# Altium schematic sheet MINIECO-ECO-COMUNICAZIONI.SchDoc(MINIECO-ECO-COMUNICAZIONI)
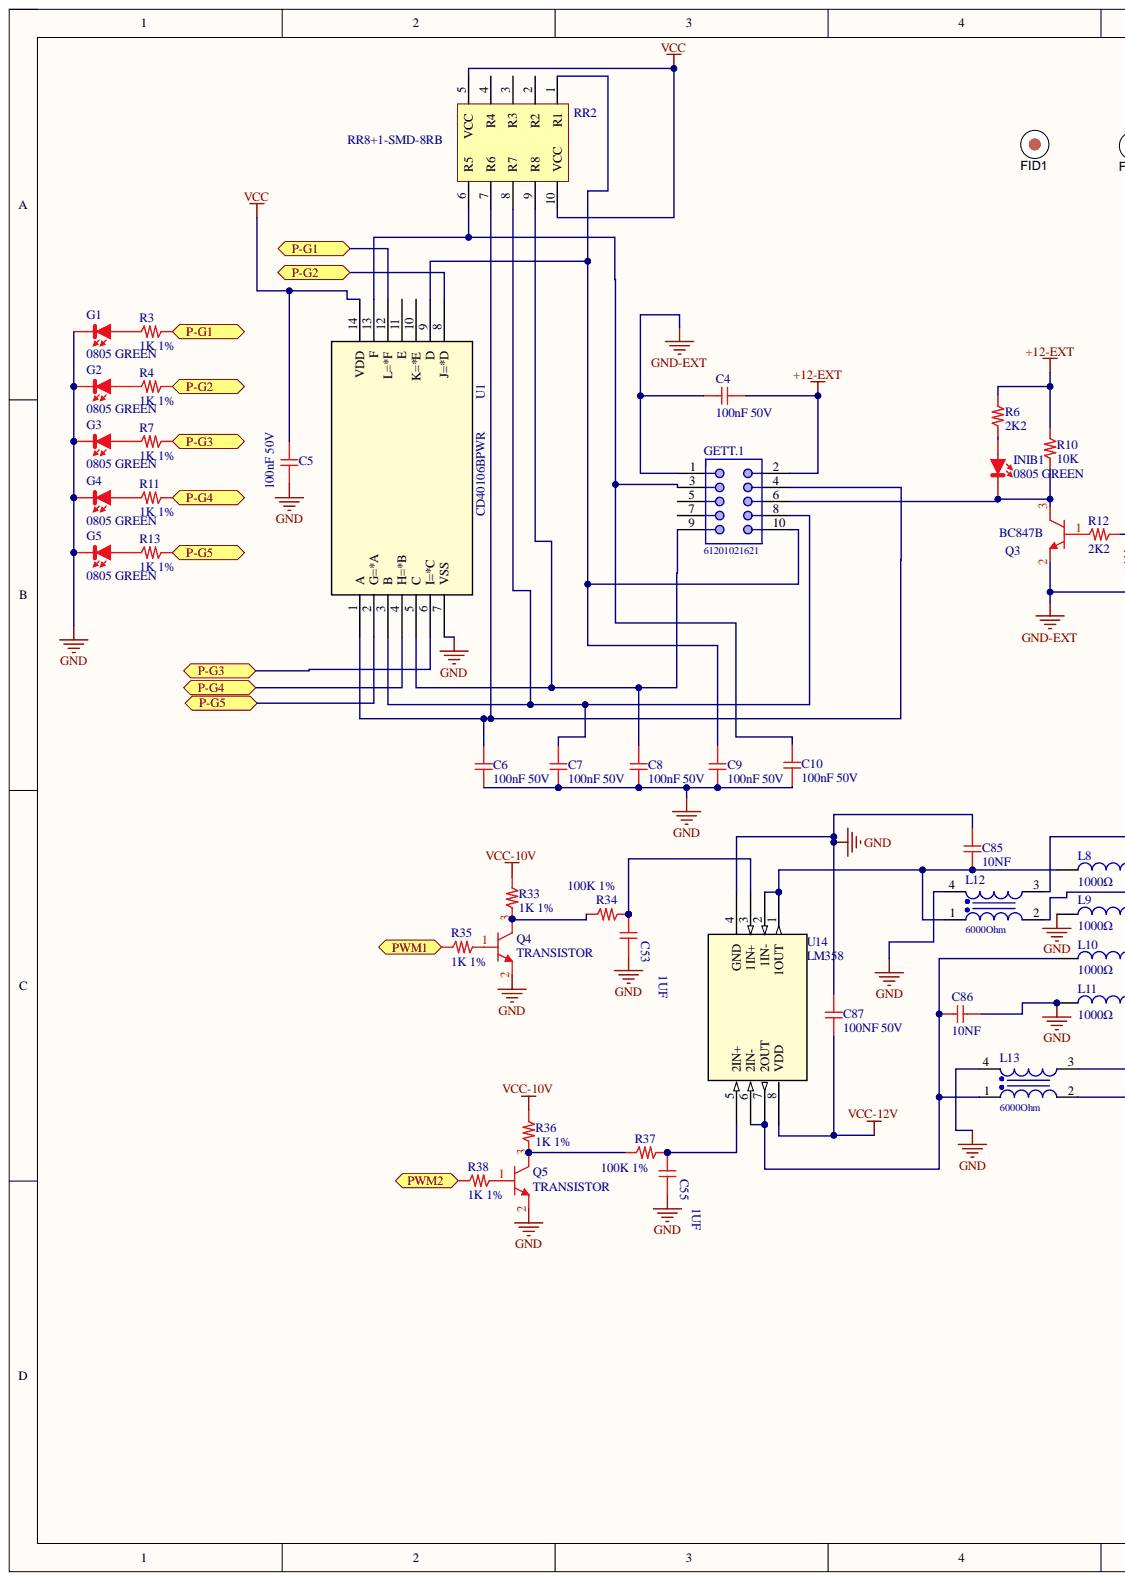
[diagram p0: MINIECO-ECO-COMUNICAZIONI.SchDoc, left]
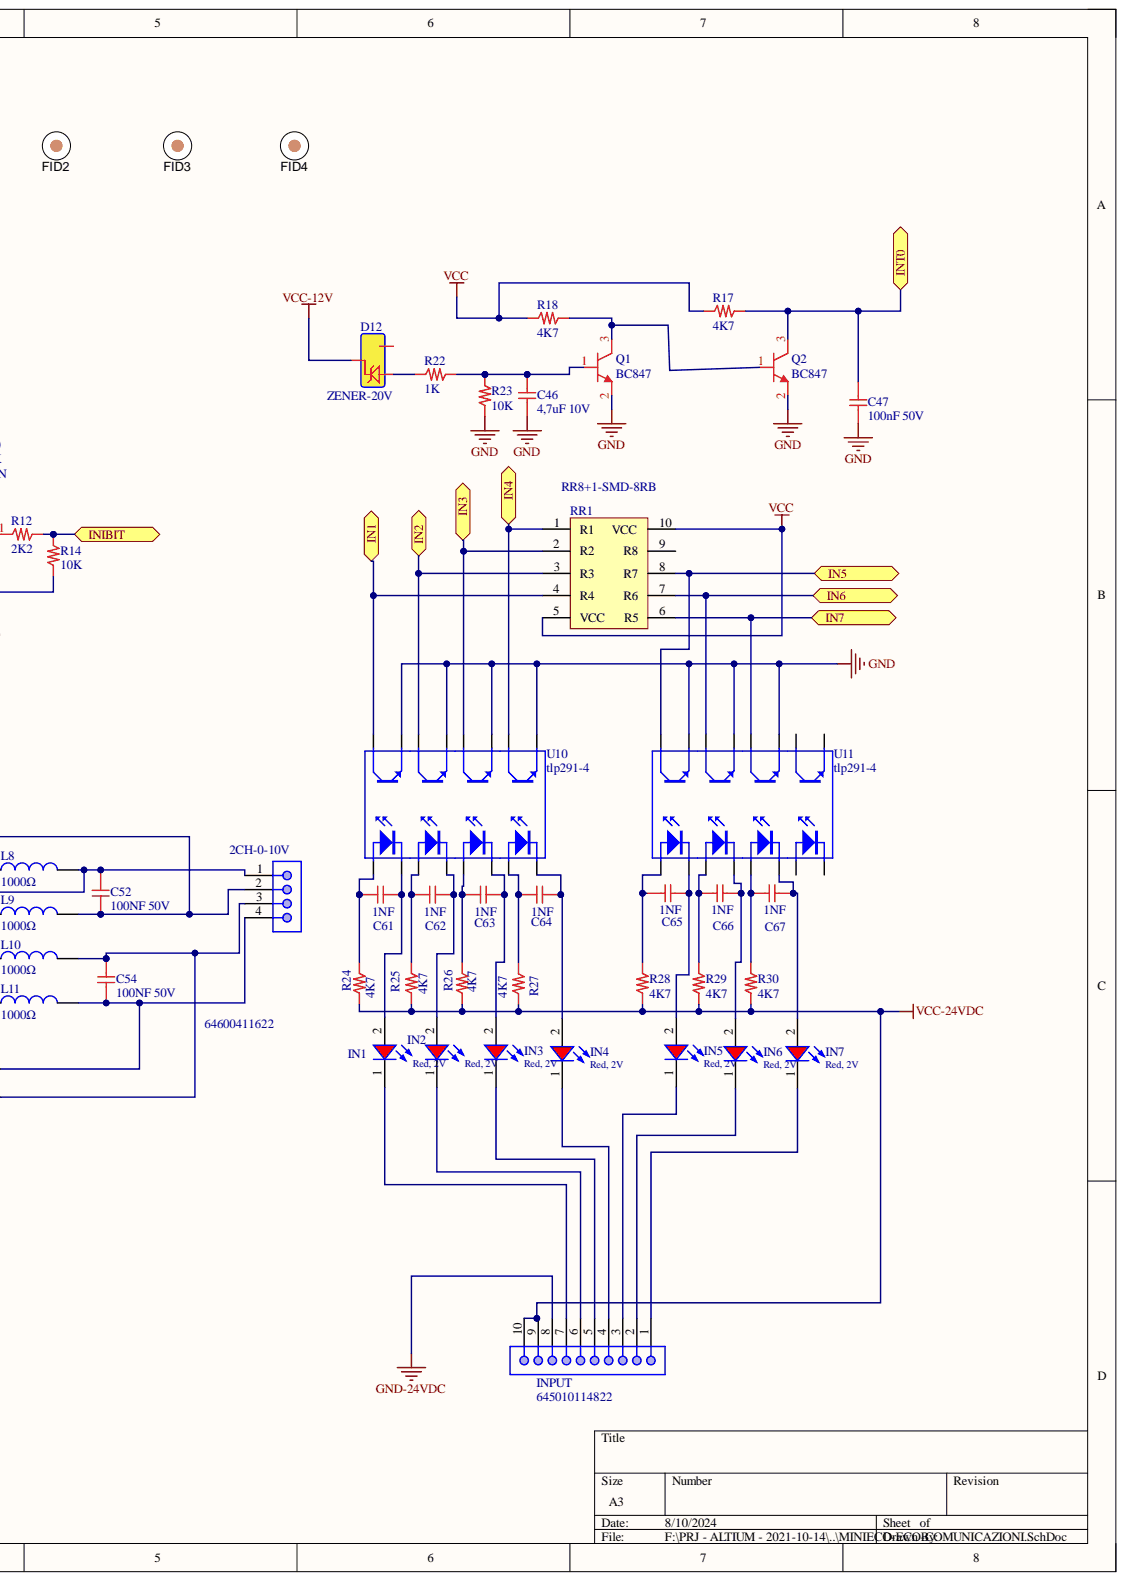
[diagram p1: MINIECO-ECO-COMUNICAZIONI.SchDoc, right]

## Parts
2CH-0-10V  64600411622  [64600411622]
C4  100nF 50V  [0603_c]
C5  100nF 50V  [0603_c]
C6  100nF 50V  [0603_c]
C7  100nF 50V  [0603_c]
C8  100nF 50V  [0603_c]
C9  100nF 50V  [0603_c]
C10  100nF 50V  [0603_c]
C46  4,7uF 10V  [0603_c]
C47  100nF 50V  [0603_c]
C52  100NF 50V  [0603_c]
C53  1UF  [0805_C]
C54  100NF 50V  [0603_c]
C55  1UF  [0805_C]
C61  1NF  [0402_C]
C62  1NF  [0402_C]
C63  1NF  [0402_C]
C64  1NF  [0402_C]
C65  1NF  [0402_C]
C66  1NF  [0402_C]
C67  1NF  [0402_C]
C85  10NF  [0603_c]
C86  10NF  [0603_c]
C87  100NF 50V  [0603_c]
D12  ZENER-20V  [SOT23]
FID1  FIDUCIAL-HSW  [FIDUCIAL HSW]  (value not drawn)
FID2  FIDUCIAL-HSW  [FIDUCIAL HSW]  (value not drawn)
FID3  FIDUCIAL-HSW  [FIDUCIAL HSW]  (value not drawn)
FID4  FIDUCIAL-HSW  [FIDUCIAL HSW]  (value not drawn)
G1  0805 GREEN  [0805_Red]
G2  0805 GREEN  [0805_Red]
G3  0805 GREEN  [0805_Red]
G4  0805 GREEN  [0805_Red]
G5  0805 GREEN  [0805_Red]
GETT.1  61201021621  [61201021621]
IN1  Red, 2V  [WL-SMCW_0603_H=0.25mm]
IN2  Red, 2V  Forward Voltage typ.: 2V  [WL-SMCW_0603_H=0.25mm]
IN3  Red, 2V  Forward Voltage typ.: 2V  [WL-SMCW_0603_H=0.25mm]
IN4  Red, 2V  Forward Voltage typ.: 2V  [WL-SMCW_0603_H=0.25mm]
IN5  Red, 2V  Forward Voltage typ.: 2V  [WL-SMCW_0603_H=0.25mm]
IN6  Red, 2V  Forward Voltage typ.: 2V  [WL-SMCW_0603_H=0.25mm]
IN7  Red, 2V  Forward Voltage typ.: 2V  [WL-SMCW_0603_H=0.25mm]
INIB1  0805 GREEN  [0805_Red]
INPUT  645010114822  [645010114822]
L8  1000Ω  [WE-CBF_0805_Wide Band]
L9  1000Ω  [WE-CBF_0805_Wide Band]
L10  1000Ω  [WE-CBF_0805_Wide Band]
L11  1000Ω  [WE-CBF_0805_Wide Band]
L12  6000Ohm  [WE-CNSW_1206]
L13  6000Ohm  [WE-CNSW_1206]
Q1  BC847  [SOT23]
Q2  BC847  [SOT23]
Q3  BC847B  [SOT23]
Q4  TRANSISTOR  [SOT23]
Q5  TRANSISTOR  [SOT23]
R3  1K 1%  [0603_R]
R4  1K 1%  [0603_R]
R6  2K2  [0603_R]
R7  1K 1%  [0603_R]
R10  10K  [0603_R]
R11  1K 1%  [0603_R]
R12  2K2  [0603_R]
R13  1K 1%  [0603_R]
R14  10K  [0603_R]
R17  4K7  [0603_R]
R18  4K7  [0603_R]
R22  1K  [0603_R]
R23  10K  [0603_R]
R24  4K7  [2512_R]
R25  4K7  [2512_R]
R26  4K7  [2512_R]
R27  4K7  [2512_R]
R28  4K7  [2512_R]
R29  4K7  [2512_R]
R30  4K7  [2512_R]
R33  1K 1%  [0603_R]
R34  100K 1%  [0603_R]
R35  1K 1%  [0603_R]
R36  1K 1%  [0603_R]
R37  100K 1%  [0603_R]
R38  1K 1%  [0603_R]
RR1  RR8+1-SMD-8RB  [RR8+1-SMD]
RR2  RR8+1-SMD-8RB  [RR8+1-SMD]
U1  CD40106BPWR  [PW14-M]
U10  tlp291-4  [SO16_NO]
U11  tlp291-4  [SO16_NO]
U14  LM358  [SO-8]

## Ports
IN1, IN2, IN3, IN4, IN5, IN6, IN7, INIBIT, INT0, P-G1, P-G2, P-G3, P-G4, P-G5, PWM1, PWM2

## Nets
+12-EXT: C4.2 GETT.1.2 R6.1 R10.1
GND: C5.1 C6.1 C7.1 C8.1 C9.1 C10.1 C46.2 C47.2 C53.2 C55.2 C85.1 C86.2 C87.2 G1.K G2.K G3.K G4.K G5.K L9.1 L11.1 L12.4 L13.4 Q1.2 Q2.2 Q4.2 Q5.2 R23.2 U1.7(VSS) U10.9 U10.11 U10.13 U10.15 U11.11 U11.13 U11.15 U14.4(GND)
GND-24VDC: INPUT.8
GND-EXT: C4.1 GETT.1.1 Q3.2 R14.2
VCC: C5.2 R17.2 R18.2 RR1.5(VCC) RR1.10(VCC) RR2.5(VCC) RR2.10(VCC) U1.14(VDD)
VCC-10V: R33.1 R36.1
VCC-12V: C87.1 D12.3 U14.8(VDD)
VCC-24VDC: INPUT.9 INPUT.10 R24.2 R25.2 R26.2 R27.2 R28.2 R29.2 R30.2
IN1: RR1.4(R4) U10.16 ; port IN1
IN2: RR1.3(R3) U10.14 ; port IN2
IN3: RR1.2(R2) U10.12 ; port IN3
IN4: RR1.1(R1) U10.10 ; port IN4
IN5: RR1.8(R7) U11.16 ; port IN5
IN6: RR1.7(R6) U11.14 ; port IN6
IN7: RR1.6(R5) U11.12 ; port IN7
INIBIT: R12.2 R14.1 ; port INIBIT
INT0: C47.1 Q2.3 R17.1 ; port INT0
P-G1: R3.2 U1.12(L=*F) ; port P-G1
P-G2: R4.2 U1.8(J=*D) ; port P-G2
P-G3: R7.2 U1.6(I=*C) ; port P-G3
P-G4: R11.2 U1.4(H=*B) ; port P-G4
P-G5: R13.2 U1.2(G=*A) ; port P-G5
PWM1: R35.1 ; port PWM1
PWM2: R38.1 ; port PWM2
Net2CH-0-10V_1: 2CH-0-10V.1 C52.1 L8.2 L12.2
Net2CH-0-10V_2: 2CH-0-10V.2 C52.2 L9.2 L12.3
Net2CH-0-10V_3: 2CH-0-10V.3 C54.1 L10.2 L13.2
Net2CH-0-10V_4: 2CH-0-10V.4 C54.2 L11.2 L13.3
NetC6_2: C6.2 GETT.1.4 RR2.7(R6) U1.1(A)
NetC7_2: C7.2 GETT.1.8 RR2.8(R7) U1.3(B)
NetC8_2: C8.2 GETT.1.9 RR2.9(R8) U1.5(C)
NetC9_2: C9.2 GETT.1.10 RR2.1(R1) U1.9(D)
NetC10_2: C10.2 GETT.1.3 RR2.6(R5) U1.13(F)
NetC46_1: C46.1 Q1.1 R22.1 R23.1
NetC53_1: C53.1 R34.2 U14.3(1IN+)
NetC55_1: C55.1 R37.2 U14.5(2IN+)
NetC61_1: C61.1 R24.1 U10.1
NetC61_2: C61.2 IN1.2 U10.2
NetC62_1: C62.1 R25.1 U10.3
NetC62_2: C62.2 IN2.2 U10.4
NetC63_1: C63.1 R26.1 U10.5
NetC63_2: C63.2 IN3.2 U10.6
NetC64_1: C64.1 R27.1 U10.7
NetC64_2: C64.2 IN4.2 U10.8
NetC65_1: C65.1 R28.1 U11.1
NetC65_2: C65.2 IN5.2 U11.2
NetC66_1: C66.1 R29.1 U11.3
NetC66_2: C66.2 IN6.2 U11.4
NetC67_1: C67.1 R30.1 U11.5
NetC67_2: C67.2 IN7.2 U11.6
NetC85_2: C85.2 L8.1 L12.1 U14.1(1OUT) U14.2(1IN-)
NetC86_1: C86.1 L10.1 L13.1 U14.6(2IN-) U14.7(2OUT)
NetD12_1: D12.1 R22.2
NetG1_A: G1.A R3.1
NetG2_A: G2.A R4.1
NetG3_A: G3.A R7.1
NetG4_A: G4.A R11.1
NetG5_A: G5.A R13.1
NetGETT.1_6: GETT.1.6 INIB1.K Q3.3 R10.2
NetIN1_1: IN1.1 INPUT.7
NetIN2_1: IN2.1 INPUT.6
NetIN3_1: IN3.1 INPUT.5
NetIN4_1: IN4.1 INPUT.4
NetIN5_1: IN5.1 INPUT.3
NetIN6_1: IN6.1 INPUT.2
NetIN7_1: IN7.1 INPUT.1
NetINIB1_A: INIB1.A R6.2
NetQ1_3: Q1.3 Q2.1 R18.1
NetQ3_1: Q3.1 R12.1
NetQ4_1: Q4.1 R35.2
NetQ4_3: Q4.3 R33.2 R34.1
NetQ5_1: Q5.1 R38.2
NetQ5_3: Q5.3 R36.2 R37.1

Not connected: D12 2; GETT.1 5 7; RR1 9(R8); RR2 2(R2) 3(R3) 4(R4); U1 10(K=*E) 11(E); U11 7 8 9 10

## Library data of the parts
2CH-0-10V = 64600411622: WR-WTB Male Vertical Shrouded Header, pitch 2.5mm, 4p; Contact Resistance: 20mOhm; Pin Number: 4; Rated Current: 3A; Withstanding Voltage: 1000VAC; Working Voltage: 250VAC; Component Kind: Standard; ComponentLink1Description: Manufacturer; ComponentLink1URL: http://www.we-online.de; ComponentLink2Description: Datasheet; ComponentLink2URL: https://www.we-online.com/catalog/datasheet/64600411622.pdf; Gender: Male; Library Name: WR-WTB_2.50mm.SchLib; Mounting Technology: THT; Operating Temperature: -40 to +105degC; Packaging: Bag; Part Number: 64600411622; Pitch: 2.5mm; Published Date: January 2020; Type: Vertical; Publisher: Wurth Elektronik
C4-C10 C46 C47 C52 C54 C87 = 100NF 50V: Cap X7R 100nF 50V Flex Terminal; Cod. Interno: COCS50665050104; Cod. Produttore: CL10B104KB8SFNC; Produttore: Samsung; Cod. DigiKey: 1276-1936-2-ND; Component Kind: Standard; Library Name: CapSMD0603.SchLib
C53 C55 = 1UF 100V: Cap X7S 1UF 100V; Cod. Interno: COCS 567061010105; Cod. Produttore: C2012X7S2A105K125AE; Produttore: TDK; Cod. DigiKey: 445-8887-2-ND; Component Kind: Standard; Library Name: CapSMD0805.SchLib; Temperature Range: Da -55°C a +125°C
C61-C67 = 1NF 50V: Cap X7R 1NF 50V; Cod. Interno: COCS556565000102; Cod. Produttore: ??; Component Kind: Standard; Library Name: CapSMD0402.SchLib; Produttore: ??
C85 C86 = 10NF 50V: Cap X7R 10nF 50V; Cod. Interno: COCS 556655000103; Cod. Produttore: CL05B103KB5NNNC; Produttore: Samsung; Cod. DigiKey: 1276-1028-2-ND; Component Kind: Standard; Library Name: CapSMD0603.SchLib
D12 = CMPZ5241B: DIODE ZENER 11V 300MW SOT23; Cod. Interno: ZENS 620CMPZ5241B; Produttore: Central Semiconductor; Vz: 10.45V - 11.55V; Cod. Produttore: CMPZ5241B; Component Kind: Standard; Library Name: DiodiZENER.SchLib
FID1-FID4 = FIDUCIAL-HSW: Fiducial mark.  There is nothing to buy or mount.; SymbolCreator: codlsc; ClassName: FiducialMark; Component Kind: Standard; CompType: Fiducial; LatestRevisionDate: 2015-10-18; LatestRevisionNote: Added Alternate PartNumber and Alternate Manufacturer parameters to appropriate components.; Library Name: HSW.SchLib; Manufacturer: N/A; MountType: N/A; PackageReference: N/A; PartNumber: N/A; SymbolVersion: 2009-11-4; Publisher: Texas Instruments
G1-G5 INIB1 = LED_ROSSO_0805: LED VERDE 0805; Cod. Interno: LEDS 2AC080RS7500; Cod. Produttore: 150080RS75000; Luminosità: 150mcd; Produttore: WE; V: 2.0V; Component Kind: Standard; Library Name: DiodiLED.SchLib
GETT.1 = 61201021621: Male Box Header WR-BHD, THT, Vertical, pitch 2.54 mm, 10 pins; Contact Resistance: 20 mOhm; Pin Number: 10; Pitch: 2.54 mm; Withstanding Voltage: 500 VAC; Working Voltage: 250 VAC; Component Kind: Standard; ComponentLink1Description: Manufacturer; ComponentLink1URL: http://www.we-online.de; ComponentLink2Description: Datasheet; ComponentLink2URL: https://katalog.we-online.de/em/datasheet/6120xx21621.pdf; Gender: Male; Mounting Technology: THT; Operating Temperature: -40 to 125 degC; Orientation: Vertical; Packaging: Tray; Part Number: 61201021621; Published Date: August 2018; Library Name: CONN-FLAT.SchLib; Publisher: Wurth Elektronik
IN1-IN7 = 150060RS75020: WL-SMCW SMT Mono-color Chip LED Waterclear, size 0603, Red, 2V, 140deg; Chip Technology: AlInGaP; Dominant Wavelength typ.: 625nm; Luminous Intensity typ.: 100mcd; Peak Wavelength typ.: 630nm; Component Kind: Standard; ComponentLink1Description: Manufacturer; ComponentLink1URL: http://www.we-online.de; ComponentLink2Description: Datasheet; ComponentLink2URL: https://www.we-online.com/catalog/datasheet/150060RS75020.pdf; Emitting Color: Red; Library Name: WL-SMCW_0603.SchLib; Mounting Technology: SMT; Operating Temperature: -40 to +85degC; Packaging: Tape and Reel; Part Number: 150060RS75020; Published Date: March 2020; Size: 0603; Viewing Angle: 140deg; Publisher: Wurth Elektronik
  IN1: Forward Voltage typ.: 2V
INPUT = 645010114822: WR-WTB THT Male Vertical Locking Header, pitch 3.96mm, 10p; Contact Resistance: 20mOhm; Pin Number: 10; Rated Current: 7A; Withstanding Voltage: 1500VAC; Working Voltage: 250VAC; Component Kind: Standard; ComponentLink1Description: Manufacturer; ComponentLink1URL: http://www.we-online.de; ComponentLink2Description: Datasheet; ComponentLink2URL: https://www.we-online.com/catalog/datasheet/645010114822.pdf; Gender: Male; Library Name: WR-WTB_3.96mm.SchLib; Mounting Technology: THT; Operating Temperature: -40 to +105degC; Packaging: Bag; Part Number: 645010114822; Pitch: 3.96mm; Published Date: January 2020; Type: Vertical; Publisher: Wurth Elektronik
L8-L11 = WE-CBF, 74279205: WE-CBF SMD EMI Suppression Ferrite Bead, 0805, 1000Ω; Type: Wide Band; Component Kind: Standard; ComponentLink1Description: Manufacturer; ComponentLink1URL: http://www.we-online.de; ComponentLink2Description: Datasheet; ComponentLink2URL: http://katalog.we-online.de/pbs/datasheet/74279205.pdf; Library Name: WE-CBF_0805.SchLib; PartNumber: 74279205; PublishedDate: Aug 2016; Size: 0805; Value: 1000Ω; Publisher: Wurth Elektronik
L12 L13 = 744232101: WE-CNSW SMT Common Mode Line Filter, size 1206, 6000Ohm, 0.09A, 50V; Manufacturer: Wurth Elektronik; DC Resistance max.: 5.5Ohm; Impedance Test Condition: 100MHz; Insulation Test Voltage: 125VAC; Winding Style: bifiliar; Component Kind: Standard; ComponentLink1Description: Manufacturer; ComponentLink1URL: http://www.we-online.de; ComponentLink2Description: Datasheet; ComponentLink2URL: https://www.we-online.com/catalog/datasheet/744232101.pdf; Impedance: 6000Ohm; Library Name: WE-CNSW_1206.SchLib; Manufacturer Part Number: 744232101; Mounting Technology: SMT; Operating Temperature: -40 to +125degC; Packaging: Tape and Reel; Published Date: April 2020; Rated Current: 0.09A; Rated Voltage: 50V; Size: 1206
Q1 Q2 = MMBT3904LT1G: NPN Si Digital Transistor; Cod. Interno: TRXS 110MMBT3904LT1G; Ft: 300MHz; Ic: 200mA; Produttore: ON Semiconductor; Ptot: 300mW; Vcb: 60V; Vce: 40V; Cod. Produttore: MMBT3904LT1G; Component Kind: Standard; Library Name: TransitorSMD.SCHLIB
Q3-Q5 = BC847B: NPN Silicon Darlington Transistors; Cod. Interno: TRXS ??; Cod. Produttore: BCV27; Ic: 500mA; Produttore: INFINEON; Vcb: 40V; Vce: 30V; Component Kind: Standard; Library Name: HSW.SchLib
R3 R4 R7 R11 R13 R33 R35 R36 R38 = 1K 1%: Res Film Spesso 1/16W 1K 1%; Cod. Interno: RESS4166356B0102; Cod. Produttore: ??; Component Kind: Standard; Library Name: ResSMD0603.SchLib; Produttore: ??
R6 R12 = 2K2: Res Film Spesso 1/16W 2K2 5%; Cod. Interno: RESS4166556B0222; Cod. Produttore: ??; Component Kind: Standard; Library Name: ResSMD0603.SchLib; Produttore: ??
R10 R14 = 10K: Res Film Spesso 1/16W 10K 5%; Cod. Interno: RESS4166556B0103??; Cod. Produttore: ??; Component Kind: Standard; Library Name: ResSMD0603.SchLib; Produttore: ??
R17 R18 R22 R23 = 2K7: Res Film Spesso 1/16W 2K7 5%; Cod. Interno: RESS4166556B0272; Cod. Produttore: CRCW06032K70JNEA; Produttore: VISHAY; Component Kind: Standard; Library Name: ResSMD0603.SchLib
R24-R30 = 4K7: Precision Thick Film 4K7 1W 1%; Cod. Interno: RESS 4190310A54R9; Cod. Produttore: ERJ-1TNF54R9U; Produttore: Vishay Dale; Cod. DigiKey: PT54.9AFTR-ND; Component Kind: Standard; Library Name: ResSMD2512.SchLib
R34 R37 = 100K 1%: Res Film Spesso 1/16W 100K 1%; Cod. Interno: RESS4166360C0104; Cod. Produttore: ??; Component Kind: Standard; Library Name: ResSMD0603.SchLib; Produttore: ??
U1 = CD40106BPWR: CD40106B CMOS Hex Schmitt-Trigger Inverters; Manufacturer_Part_Number: CD40106BMT; Mfr_Name: Texas Instruments; Component Kind: Standard; Digi-Key_Part_Number_1: 2156-CD40106BMT-ND; Digi-Key_Part_Number_2: 296-28568-1-ND; Digi-Key_Part_Number_3: 296-28568-2-ND; Digi-Key_Part_Number_4: 296-28568-6-ND; Digi-Key_Part_Number_5: 296-CD40106BMTCT-ND; Digi-Key_Part_Number_6: 296-CD40106BMTDKR-ND; Digi-Key_Part_Number_7: 296-CD40106BMTTR-ND; RefDes: RefDes; Type: DEV; Copyright: Copyright (C) 2021 Ultra Librarian. All rights reserved.; Library Name: HSW.SchLib
U10 U11 = tlp291_4: 4-Pin Phototransistor Optocoupler; Component Kind: Standard; DatasheetVersion: Nov-2003; LatestRevisionDate: 04-Mar-2005; LatestRevisionNote: Sch pins 3 & 4 Swapped.; PackageReference: DIP-4; Published: 24-Mar-1999; Library Name: HSW.SchLib; Publisher: Altium Limited
U14 = LM358: Industry-Standard Dual Operational Amplifiers; Manufacturer_Part_Number: TLC272CDR; Built_By: EMA_UL_Team; Vendor: Texas Instruments; Component Kind: Standard; RefDes: RefDes; Type: DEV; Value: Value; Copyright: Copyright (C) 2018 Accelerated Designs. All rights reserved; Library Name: HSW.SchLib
RR1 RR2 = RR8+1-SMD-8RB: Component Kind: Standard; Library Name: HSW.SchLib
